# Altium schematic sheet Sheet.SchDoc
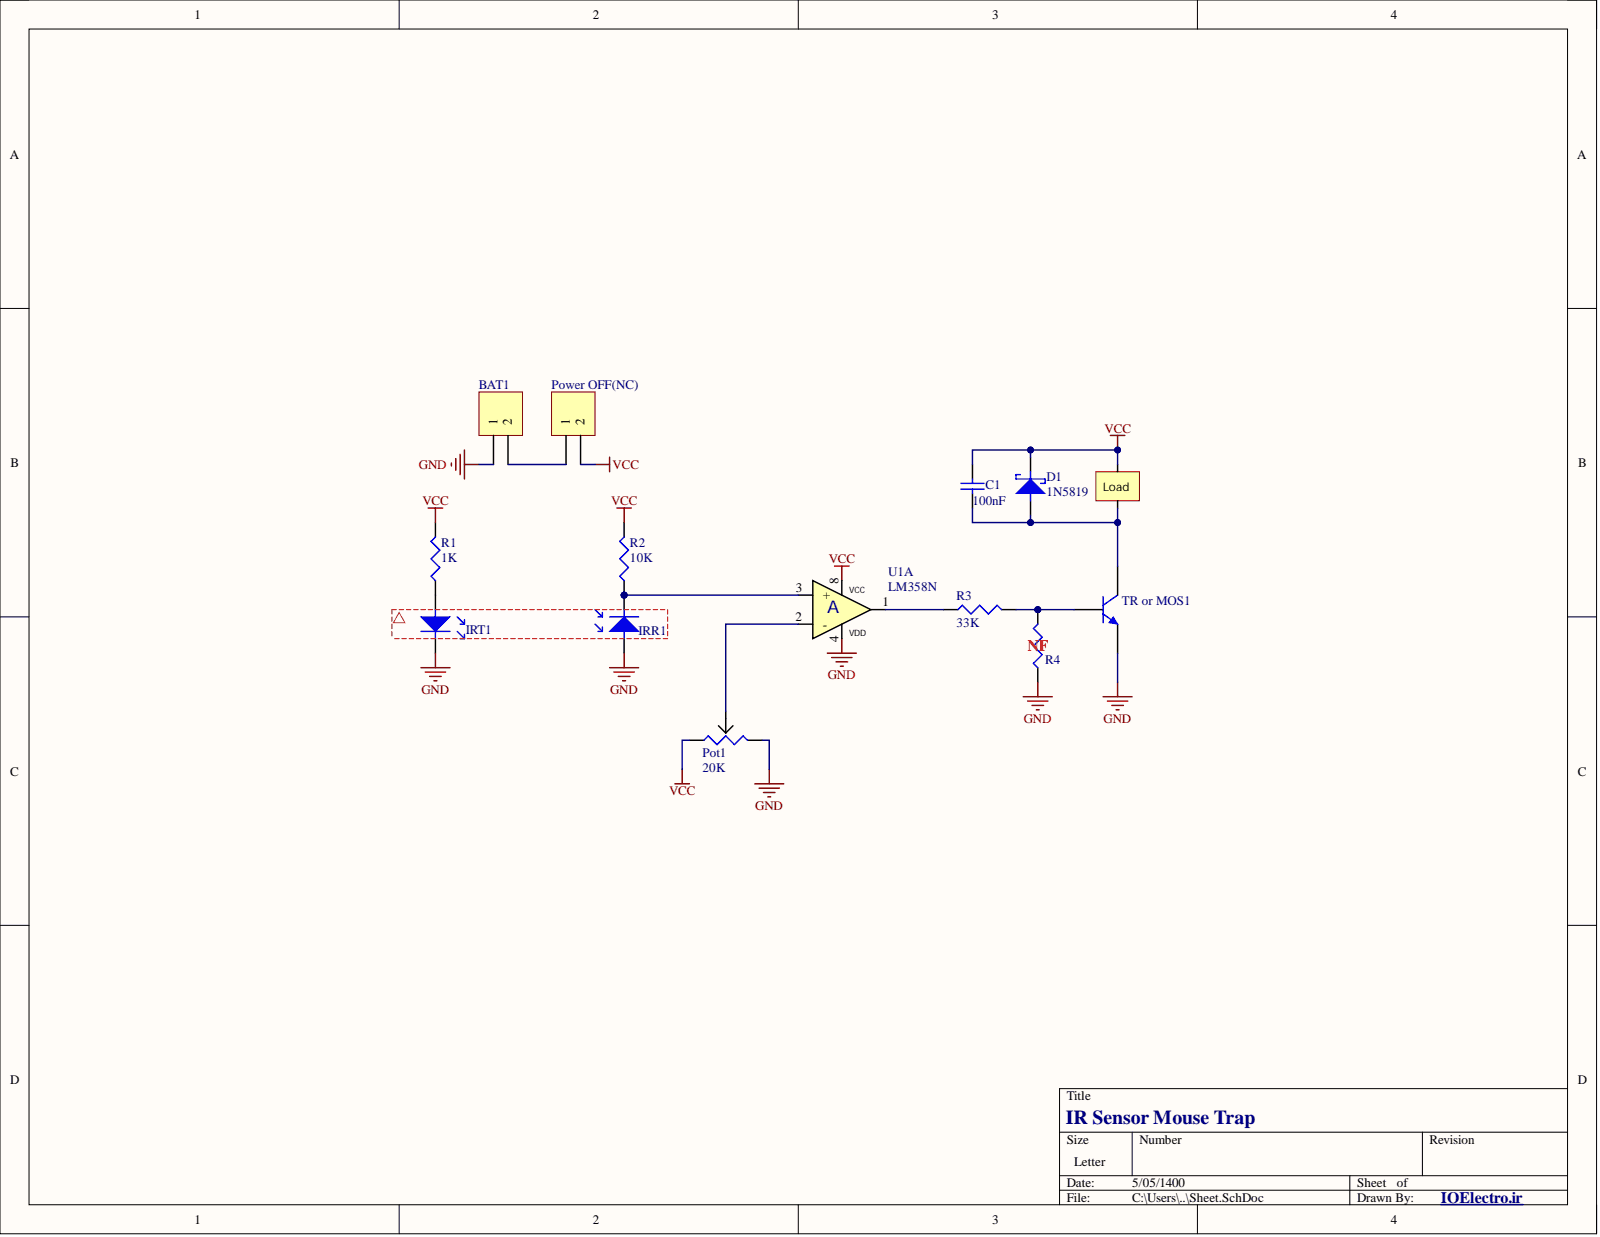
[diagram p0: Sheet.SchDoc]

## Parts
BAT1  Header 2  [HDR1X2]  (value not drawn)
C1  100nF  [CAPA_BP_2mm]
D1  1N5819
IRR1  IRR
IRT1  LED  [LED-2835]  (value not drawn)
L1  Load
Pot1  20K
Power_OFF(NC)  Header 2  [HDR1X2]  (value not drawn)
R1  1K  [R-0805]
R2  10K  [R-0805]
R3  33K  [R-0805]
R4  1K  [R-0805]  (value not drawn)
TR_or_MOS1  BC337  [TO-92-1]  (value not drawn)
U1  LM358N  [DIP_8]  (part A)

## Nets
GND: BAT1.1 IRR1.A IRT1.K Pot1.2 R4.1 TR_or_MOS1.3 U1.4
VCC: C1.2 D1.K L1.1 Pot1.1 Power_OFF(NC).2 R1.2 R2.2 U1.8
NetBAT1_2: BAT1.2 Power_OFF(NC).1
NetC1_1: C1.1 D1.A L1.2 TR_or_MOS1.1
NetIRR1_K: IRR1.K R2.1 U1.3(+)
NetIRT1_A: IRT1.A R1.1
NetPot1_3: Pot1.3 U1.2(-)
NetR3_1: R3.1 U1.1(A)
NetR3_2: R3.2 R4.2 TR_or_MOS1.2

## Library data of the parts
BAT1 Power_OFF(NC) = Header 2: Header, 2-Pin; Component Kind: Standard; LatestRevisionDate: 17-Jul-2002; LatestRevisionNote: Re-released for DXP Platform.; Library Name: Miscellaneous Connectors.IntLib; Signal Integrity: Connector; Publisher: Altium Limited
C1 = Cap_BP: Capacitor; Component Kind: Standard; Library Name: AlmaLib1.IntLib
D1 = 1N5819: Sotki Diod; Component Kind: Standard; Library Name: IOElectro.IntLib
IRT1 = LED: SMD LED 2835; Component Kind: Standard; Library Name: IOElectro.IntLib
Pot1 = Pot: Component Kind: Standard; Library Name: IOElectro.IntLib
R1-R4 = RES: SMD Resistor; Component Kind: Standard; Library Name: IOElectro.IntLib
TR_or_MOS1 = BC337: NPN Transistor; Component Kind: Standard; Library Name: AlmaLib1.IntLib
U1 = LM358N: IC OPAMP GP 1.1MHZ 8DIP; Manufacturer: STMicroelectronics; Amplifier Type: GENERAL PURPOSE; Common Mode Rejection Ratio: 70dB; Dual Supply Voltage: 9V; Input Bias Current: 150nA; Input Offset Voltage (Vos): 7mV; Max Dual Supply Voltage: 15V; Max Input Voltage: 28.5V; Max Supply Voltage: 30V; Min Dual Supply Voltage: 1.5V; Min Input Voltage: 0V; Min Supply Voltage: 3V; Nominal Supply Current: 1.2mA; Number of Amplifiers: 2; Operating Supply Current: 700uA; Output Current: 40mA; Output Current per Channel: 60mA; Slew Rate: 0.6V/µs; Voltage Gain: 100dB; Bandwidth: 1.1MHz; Case/Package: DIP; Category: Amplifiers - Op Amps, Buffer, Instrumentation; Component Kind: Standard; ComponentLink1Description: https://datasheet.ciiva.com/15130/getdatasheetpartid-85226-15130372.pdf?src-supplier=Verical; ComponentLink1URL: https://datasheet.ciiva.com/15130/getdatasheetpartid-85226-15130372.pdf?src-supplier=Verical; ComponentLink2Description: https://datasheet.ciiva.com/29306/155600-da-01-en-op-verst-lm358n-stm-29306824.pdf?src-supplier=Conrad; ComponentLink2URL: https://datasheet.ciiva.com/29306/155600-da-01-en-op-verst-lm358n-stm-29306824.pdf?src-supplier=Conrad; ComponentLink3Description: https://datasheet.ciiva.com/29305/174440-da-01-en-ic-lm-358-n-dip8-stm-29305885.pdf?src-supplier=Conrad; ComponentLink3URL: https://datasheet.ciiva.com/29305/174440-da-01-en-ic-lm-358-n-dip8-stm-29305885.pdf?src-supplier=Conrad; ComponentLink4Description: https://datasheet.ciiva.com/14151/jhlm258-e-14151239.pdf?src-supplier=Distrelec; ComponentLink4URL: https://datasheet.ciiva.com/14151/jhlm258-e-14151239.pdf?src-supplier=Distrelec; ComponentLink5Description: https://datasheet.ciiva.com/1796/cd00000464-1796450.pdf?src-supplier=Digi-Key; ComponentLink5URL: https://datasheet.ciiva.com/1796/cd00000464-1796450.pdf?src-supplier=Digi-Key; ComponentLink6Description: https://datasheet.ciiva.com/18404/70013564-18404010.pdf?src-supplier=Allied+Electronics; ComponentLink6URL: https://datasheet.ciiva.com/18404/70013564-18404010.pdf?src-supplier=Allied+Electronics; ComponentLink7Description: https://datasheet.ciiva.com/16351/cd00000464-16351087.pdf?src-supplier=Future+Electronics; ComponentLink7URL: https://datasheet.ciiva.com/16351/cd00000464-16351087.pdf?src-supplier=Future+Electronics; Gain Bandwidth Product: 1.1MHz; Height: 3.3mm; Input Offset Voltage-Max: 7mV; Lead Free: Lead Free; Length: 9.27mm; Library Name: IOElectro.IntLib; Manufacturer Part Number: LM358N; Max Operating Temperature: 70°C; Min Operating Temperature: 0°C; Mount: Through Hole; Number of Channels: 2; Number of Circuits: 2; Number of Elements: 2; Number of Pins: 8; Operating Supply Voltage: 15V; Package Quantity: 50; Packaging: Rail/Tube; Radiation Hardening: No; REACH SVHC: No SVHC; RoHS: Yes; RoHS Compliant: Yes; Series: -; Slew Rate-Nom: 0.6V/µs; Supplier 1: Digi-Key; Supplier Part Number 1: 497-1592-5-ND; Supply Current: 1.2mA; Technology: BIPOLAR; Unity Gain Bandwidth Product: 1.1MHz; Weight: 0.01lb; Width: 6.35mm
IRR1 = IRR: Component Kind: Standard; Library Name: IOElectro.IntLib
L1 = Load: Component Kind: Standard; Library Name: IOElectro.IntLib
